# Altium schematic sheet Debug Schematic Prints("All Documents",Physical)
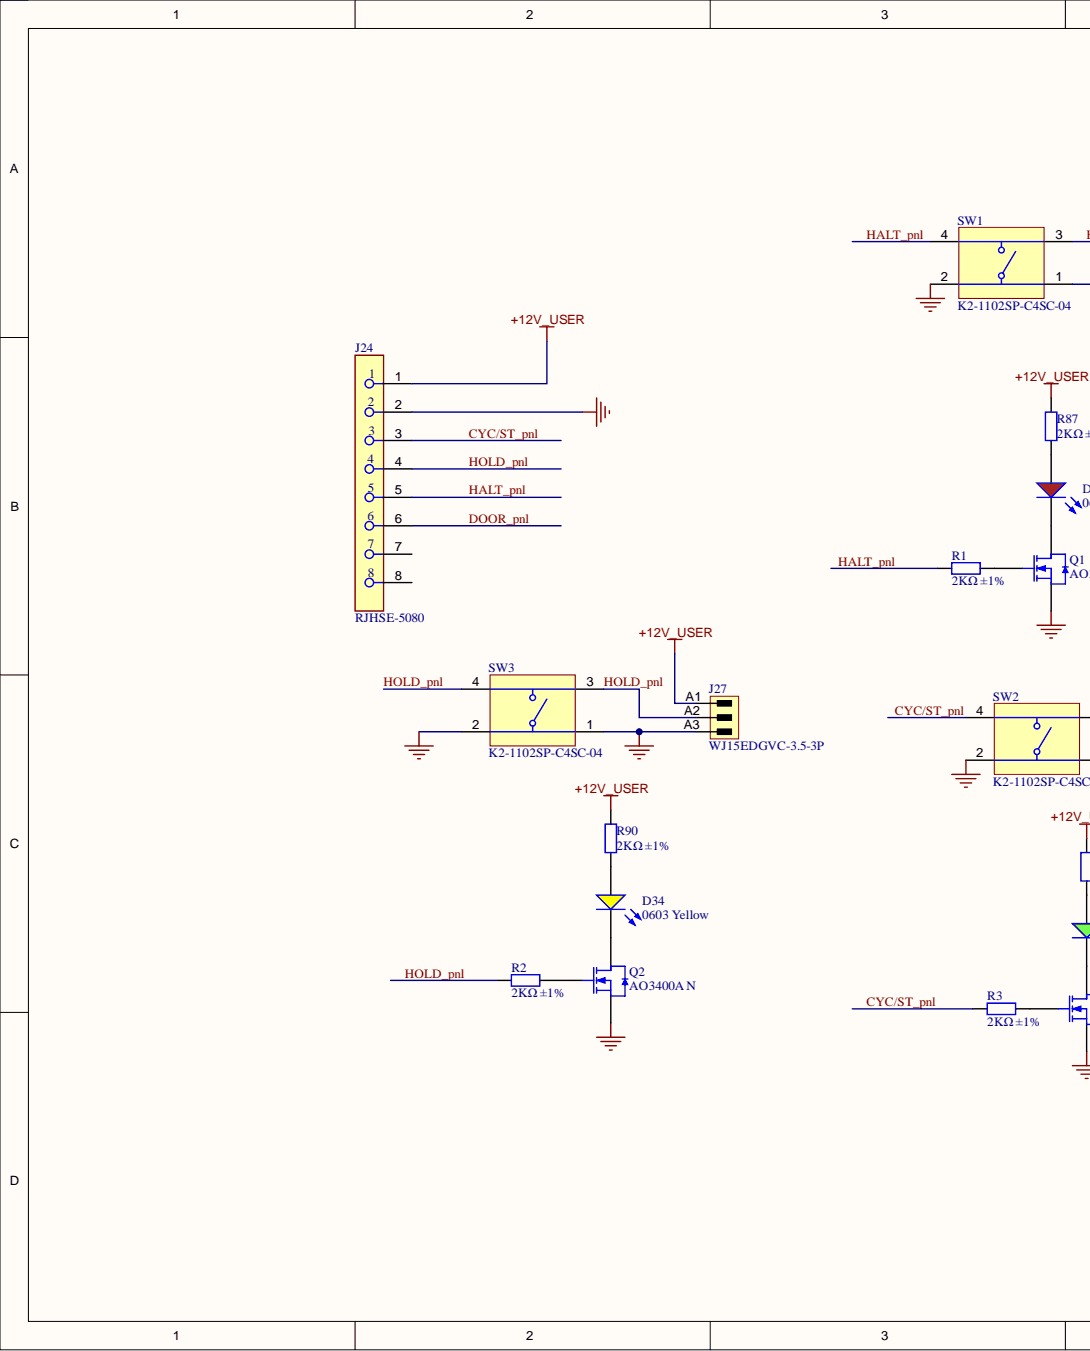
[diagram p0: Debug Schematic Prints("All Documents",Physical), left]
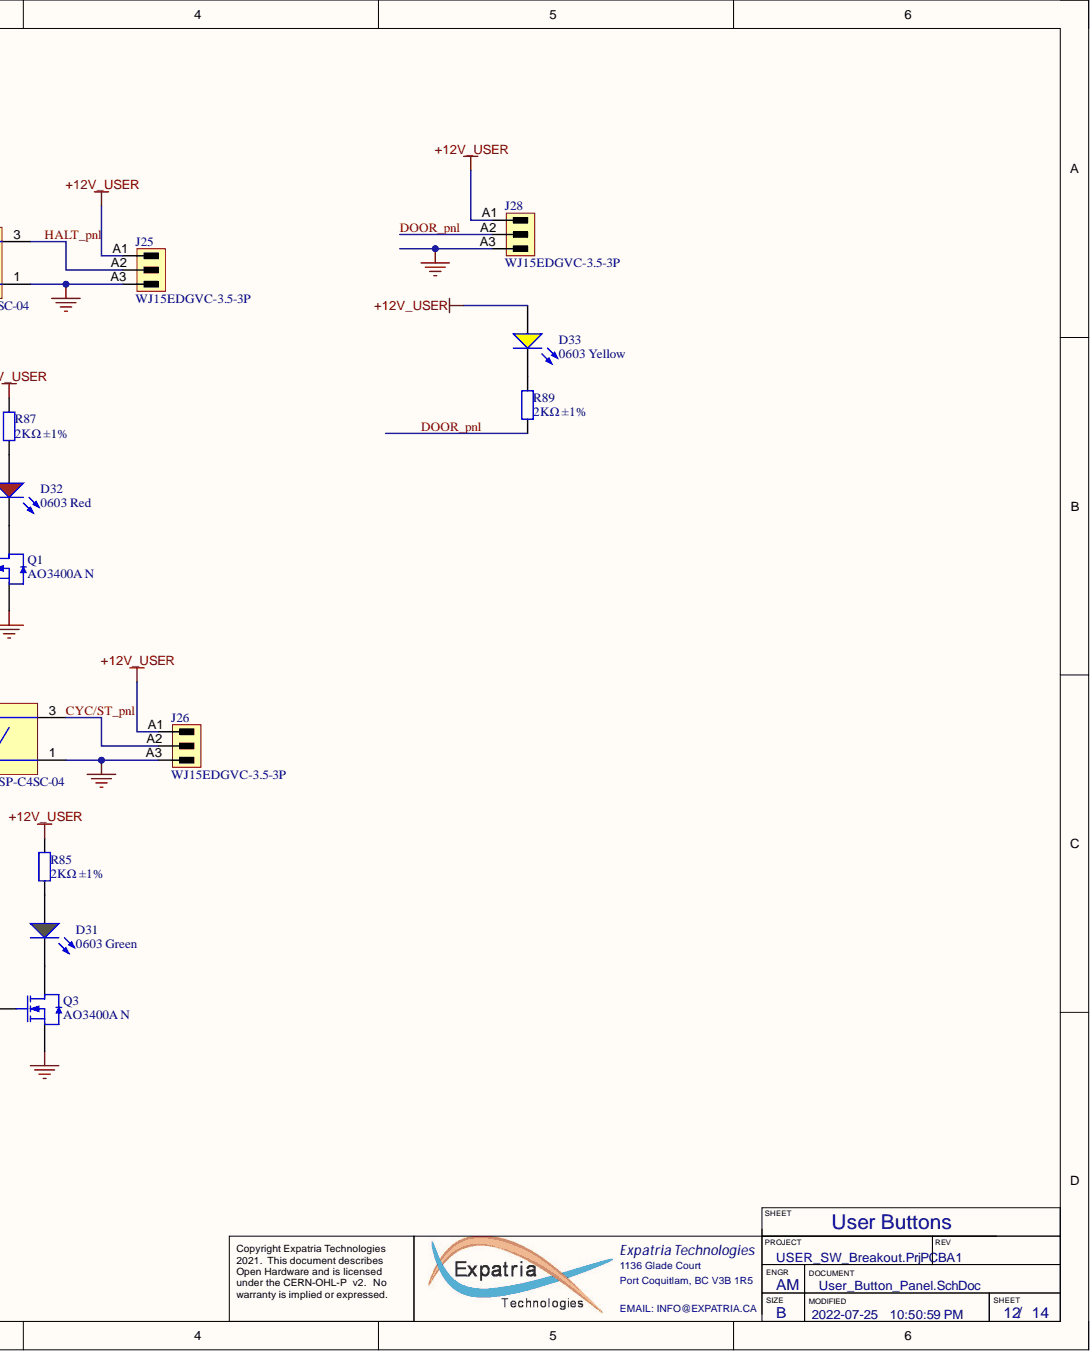
[diagram p1: Debug Schematic Prints("All Documents",Physical), right]

## Parts
D31  0603 Green  Color: Green  Package: 0603  [0603_LED_S1]
D32  0603 Red  Color: Red  Package: 0603  [0603_LED_S1]
D33  0603 Yellow  Color: Yellow  Package: 0603  [0603_LED_S1]
D34  0603 Yellow  Color: Yellow  Package: 0603  [0603_LED_S1]
J24  RJHSE-5080  [FP-RJHSE-5080-MFG]
J25  WJ15EDGVC-3.5-3P  [SL_3_50_180G_03]  (no BOM)
J26  WJ15EDGVC-3.5-3P  [SL_3_50_180G_03]  (no BOM)
J27  WJ15EDGVC-3.5-3P  [SL_3_50_180G_03]  (no BOM)
J28  WJ15EDGVC-3.5-3P  [SL_3_50_180G_03]  (no BOM)
Q1  AO3400A N  [SOT-23-3L]
Q2  AO3400A N  [SOT-23-3L]
Q3  AO3400A N  [SOT-23-3L]
R1  2KΩ ±1%  Resistance: 2KΩ  [0603_R]
R2  2KΩ ±1%  Resistance: 2KΩ  [0603_R]
R3  2KΩ ±1%  Resistance: 2KΩ  [0603_R]
R85  2KΩ ±1%  Resistance: 2KΩ  [0603_R]
R87  2KΩ ±1%  Resistance: 2KΩ  [0603_R]
R89  2KΩ ±1%  Resistance: 2KΩ  [0603_R]
R90  2KΩ ±1%  Resistance: 2KΩ  [0603_R]
SW1  K2-1102SP-C4SC-04  [TECO-FSM4JSMATR_V]
SW2  K2-1102SP-C4SC-04  [TECO-FSM4JSMATR_V]
SW3  K2-1102SP-C4SC-04  [TECO-FSM4JSMATR_V]

## Nets
+12V_USER: D33.A J24.1 J25.A1 J26.A1 J27.A1 J28.A1 R85.2 R87.1 R90.1
GND_USER: J24.2 J25.A3 J26.A3 J27.A3 J28.A3 Q1.2 Q2.2 Q3.2 SW1.1 SW1.2 SW2.1 SW2.2 SW3.1 SW3.2
CYC/ST_pnl: J24.3 J26.A2 R3.2 SW2.3 SW2.4
DOOR_pnl: J24.6 J28.A2 R89.2
HALT_pnl: J24.5 J25.A2 R1.2 SW1.3 SW1.4
HOLD_pnl: J24.4 J27.A2 R2.2 SW3.3 SW3.4
NetD31_A: D31.A R85.1
NetD31_K: D31.K Q3.3
NetD32_A: D32.A R87.2
NetD32_K: D32.K Q1.3
NetD33_K: D33.K R89.1
NetD34_A: D34.A R90.2
NetD34_K: D34.K Q2.3
NetJ24_7: J24.7
NetJ24_8: J24.8
NetQ1_1: Q1.1 R1.1
NetQ2_1: Q2.1 R2.1
NetQ3_1: Q3.1 R3.1

## Library data of the parts
D31 = 0603 LED Green: LED; Component Kind: Standard; Library Name: LED.SchLib; Supplier: LC; SuppliersPartNumber: C72043
D32 = 0603 LED Red: LED; Component Kind: Standard; Library Name: LED.SchLib; Supplier: LC; SuppliersPartNumber: C2286
D33 D34 = 0603 LED Yellow: LED; Component Kind: Standard; Library Name: LED.SchLib; Supplier: LC; SuppliersPartNumber: C72038
J24 = CMP-02366-000003-1: CONN MOD JACK 8P8C R/A UNSHLD; Manufacturer: Pulse Electronics Network; Manufacturer Part Number: E5J88-00LJG2-L; Pitch: 1.02mm; Component Kind: Standard; ComponentLink2Description: Datasheet; ComponentLink2URL: https://cdn.amphenol-icc.com/media/wysiwyg/files/documentation/datasheet/inputoutput/io-modjack-rjhse.pdf; Device Class L1: Connectors; Digikey Description: CONN MOD JACK 8P8C R/A UNSHLD; Library Name: Altium Content Vault; Package: CONN_MOD_JACK; SuppliersPartNumber: C385834
J25-J28 = CMP-1559-00904-1: Header,Male Pin,Covered(4 Sided) 3 1 0.138"（3.50mm） 3 P=3.5mm Pluggable System Terminal Block RoHS; Manufacturer: Ningbo Kangnex Elec; Pitch_inch: 0.138 inch; Pitch_mm: 3.5 mm; Short_text_for_material: SL 3.50/03/180G 3.2SN OR BX; Side_termination_characteristic: closed side; Solder_pin_length_mm: 3.2 mm; UL_94_flammability_rating: V-0; PackageReference: SL_3_50_180G_03; Packaging: Box; PartNumber: WJ15EDGVC-3.5-3P; Revision: V1; SuppliersPartNumber: C192778; Library Name: PrintNC_GRBLHAL_Breakout.SCHLIB
Q1-Q3 = AO3400A N道沟 X0YV1T SOT-23: MOS(场效应管); Component Kind: Standard; ComponentLink1Description: 供应商链接; ComponentLink1URL: http://www.szlcsc.com/product/details_21629.html; Library Name: MOS.SchLib; Package: SOT-23; Supplier: LC; SuppliersPartNumber: C700953
R1-R3 R85 R87 R89 R90 = 0603 2K (2001) 1%: Chip resistor; Manufacturer: Uniroyal Elec; PartNumber: 0603WAF2001T5E; Power: 1/10W; Component Kind: Standard; ComponentLink1Description: Supplier link; ComponentLink1URL: http://www.szlcsc.com/product/details_23702.html; Package: 0603; Supplier: LC; SuppliersPartNumber: C22975; Tolerance: 1%; Library Name: PrintNC_GRBLHAL_Breakout.SCHLIB
SW1-SW3 = CMP-2000-07486-1: Top Actuated 50mA @ 12VDC Round Button SPST 6*6mm Tactile Switches ROHS; Component Kind: Standard; Library Name: Altium Content Vault; Package: SMD-4_6.0x6.0x4.5P; SuppliersPartNumber: C127509
